# Altium schematic sheet EIS_Sensoren_V1_1.SchDoc
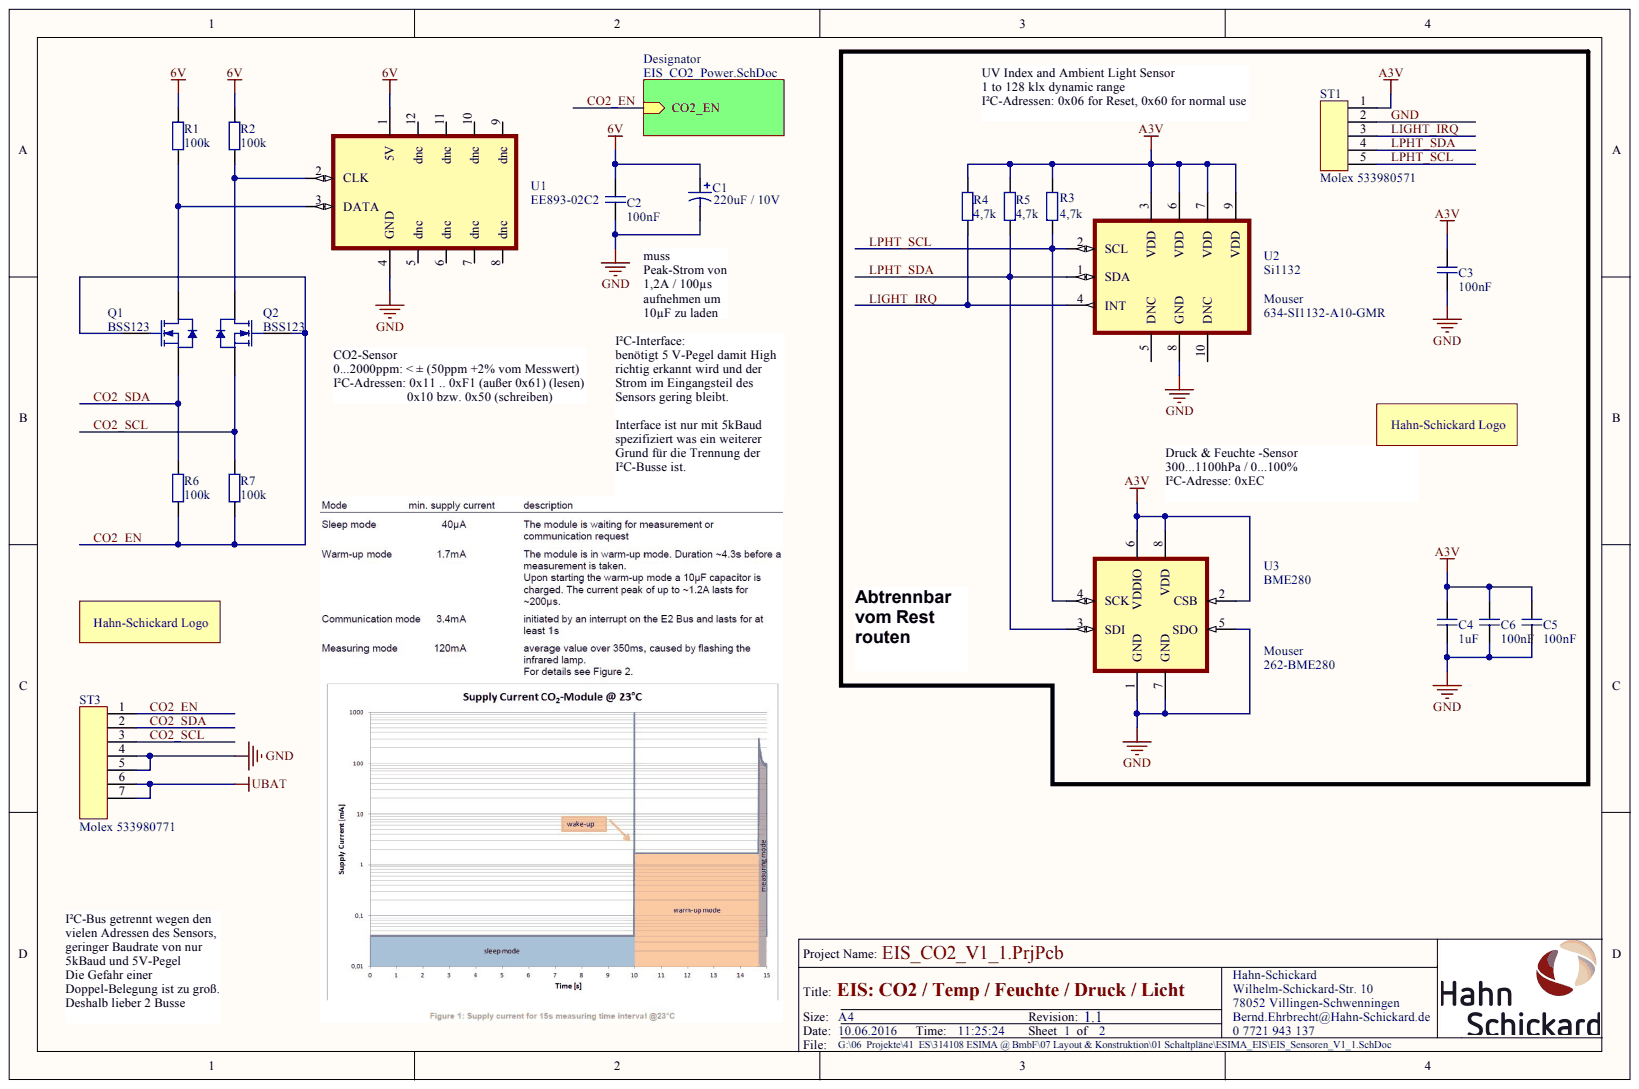
[diagram p0: EIS_Sensoren_V1_1.SchDoc]

## Parts
C1  220uF / 10V  [D]
C2  100nF  [CAPC1608N]
C3  100nF  [CAPC1608N]
C4  1uF  [CAPC3216N]
C5  100nF  [CAPC1608N]
C6  100nF  [CAPC1608N]
LOGO1  Hahn-Schickard LOGO  [Hahn-Schickard-LOGO_klein]
LOGO2  Hahn-Schickard LOGO  [Hahn-Schickard-LOGO_klein]
Q1  BSS123  [SOT23_N - BSS123]
Q2  BSS123  [SOT23_N - BSS123]
R1  100k  [RESC1608N]
R2  100k  [RESC1608N]
R3  4,7k  [RESC1608N]
R4  4,7k  [RESC1608N]
R5  4,7k  [RESC1608N]
R6  100k  [RESC1608N]
R7  100k  [RESC1608N]
ST1  Molex 533980571  [Molex 533980571]
ST3  Molex 533980771  [Molex 533980771]
U1  EE893-02C2  [EE893]
U2  Si1132  Supplier 1: Mouser  Supplier Part Number 1: 634-SI1132-A10-GMR  [Si1132]
U3  BME280  [BME280]

## Nets
6V: C1.1 C2.1 R1.2 R2.2 U1.1(5V)
A3V: C3.1 C4.1 C5.1 C6.1 R3.2 R4.2 R5.2 ST1.1 U2.3(VDD) U2.6(VDD) U2.7(VDD) U2.9(VDD) U3.2(CSB) U3.6(VDDIO) U3.8(VDD)
UBAT: ST3.6 ST3.7
CO2_EN: Q1.1 Q2.1 R6.1 R7.1 ST3.1
CO2_SCL: Q2.2 R7.2 ST3.3
CO2_SDA: Q1.2 R6.2 ST3.2
GND: C1.2 C2.2 C3.2 C4.2 C5.2 C6.2 ST1.2 ST3.4 ST3.5 U1.4(GND) U2.8(GND) U3.1(GND) U3.5(SDO) U3.7(GND)
LIGHT_IRQ: R4.1 ST1.3 U2.4(INT)
LPHT_SCL: R3.1 ST1.5 U2.2(SCL) U3.4(SCK)
LPHT_SDA: R5.1 ST1.4 U2.1(SDA) U3.3(SDI)
NetQ1_3: Q1.3 R1.1 U1.3(DATA)
NetQ2_3: Q2.3 R2.1 U1.2(CLK)

Not connected: U1 5(dnc) 6(dnc) 7(dnc) 8(dnc) 9(dnc) 10(dnc) 11(dnc) 12(dnc); U2 5(DNC) 10(DNC)

## Library data of the parts
C1 = Cap Pol3: Polarized Capacitor (Surface Mount); Component Kind: Standard; LatestRevisionDate: 17-Jul-2002; LatestRevisionNote: Re-released for DXP Platform.; Library Name: Miscellaneous Devices.SchLib; PackageReference: C0805; Published: 8-Jun-2000; Signal Integrity: Cap Pol; Simulation: CAP; Value: 220uF / 10V; Publisher: Altium Limited
C2-C6 = Kondensator SMD Keramik: Component Kind: Standard; Library Name: My_Schlib_6_18.SchLib
  C2 C3 C5 C6: Value: 100nF
  C4: Value: 1uF
LOGO1 LOGO2 = Hahn-Schickard LOGO: Hahn-Schickard Logo als Footprint; Component Kind: Standard; Library Name: Eis_Lib.SchLib
Q1 Q2 = BSS123: N-channel Enhancement Mode Vertical D-MOSFET; Component Kind: Standard; ComponentLink1Description: Manufacturer Link; ComponentLink1URL: http://www.semiconductors.philips.com/; ComponentLink2Description: Datasheet; ComponentLink2URL: http://www.semiconductors.philips.com/acrobat/datasheets/BSS123_3.pdf; DatasheetVersion: Apr-1995; LatestRevisionDate: 12-Dec-2006; LatestRevisionNote: IPC-7351 Footprint Added.; Library Name: Philips Discrete MOSFET - Low Power.IntLib; PackageDescription: 3-Pin SOT-23 Package 0.95 mm Pitch, 2.3 mm Lead Span; PackageReference: SOT23; PackageVersion: May-1999; Published: 17-Sep-2003; Simulation: BSS123; Publisher: Altium Limited
R1-R7 = RESC1608 (R0603): Suplier: Seller; Suplier Ordering Number: Ordering Number; Component Kind: Standard; ComponentLink1Description: RESC1608 (R0603) 1%; Description: RESC1608 (R0603); Published: 14.08.2009; Signal Integrity: Res; Simulation: RESISTOR; Library Name: MetaSensClampOn_BT.SchLib; Publisher: HSG Jürgen Merz
  R1 R2 R6 R7: Value: 100k
  R3-R5: Value: 4,7k
ST1 = Molex 533980571: MOLEX - 53398-0571 - STIFTLEISTE, SMT, 1.25MM, 5POL; Component Kind: Standard; Supplier 1: Farnell; Supplier Part Number 1: 2426364; Library Name: JM_Elektromechanik.SchLib
ST3 = Molex 533980771: Molex Pico 7Pol Blade SMD gerade 533980771; Component Kind: Standard; Supplier 1: Farnell; Library Name: JM_Elektromechanik.SchLib
U1 = EE893-02C2: CO2-Sensor; I²C-Interface,; Component Kind: Standard; Library Name: Eis_Lib.SchLib
U2 = Si1132: UV INDEX AND AMBIENT LIGHT SENSOR IC WITH I2C INTERFACE, QFN 2x2mm; Component Kind: Standard; Library Name: Eis_Lib.SchLib
U3 = BME280: Bosch, Druck & Feuchte-Sensor, I2C / SPI; Component Kind: Standard; Supplier 1: Mouser; Supplier Part Number 1: 262-BME280; Library Name: Eis_Lib.SchLib
